# Altium schematic sheet Eco-Stationnement_Rampe.SchDoc(Eco-Stationnement_Rampe)
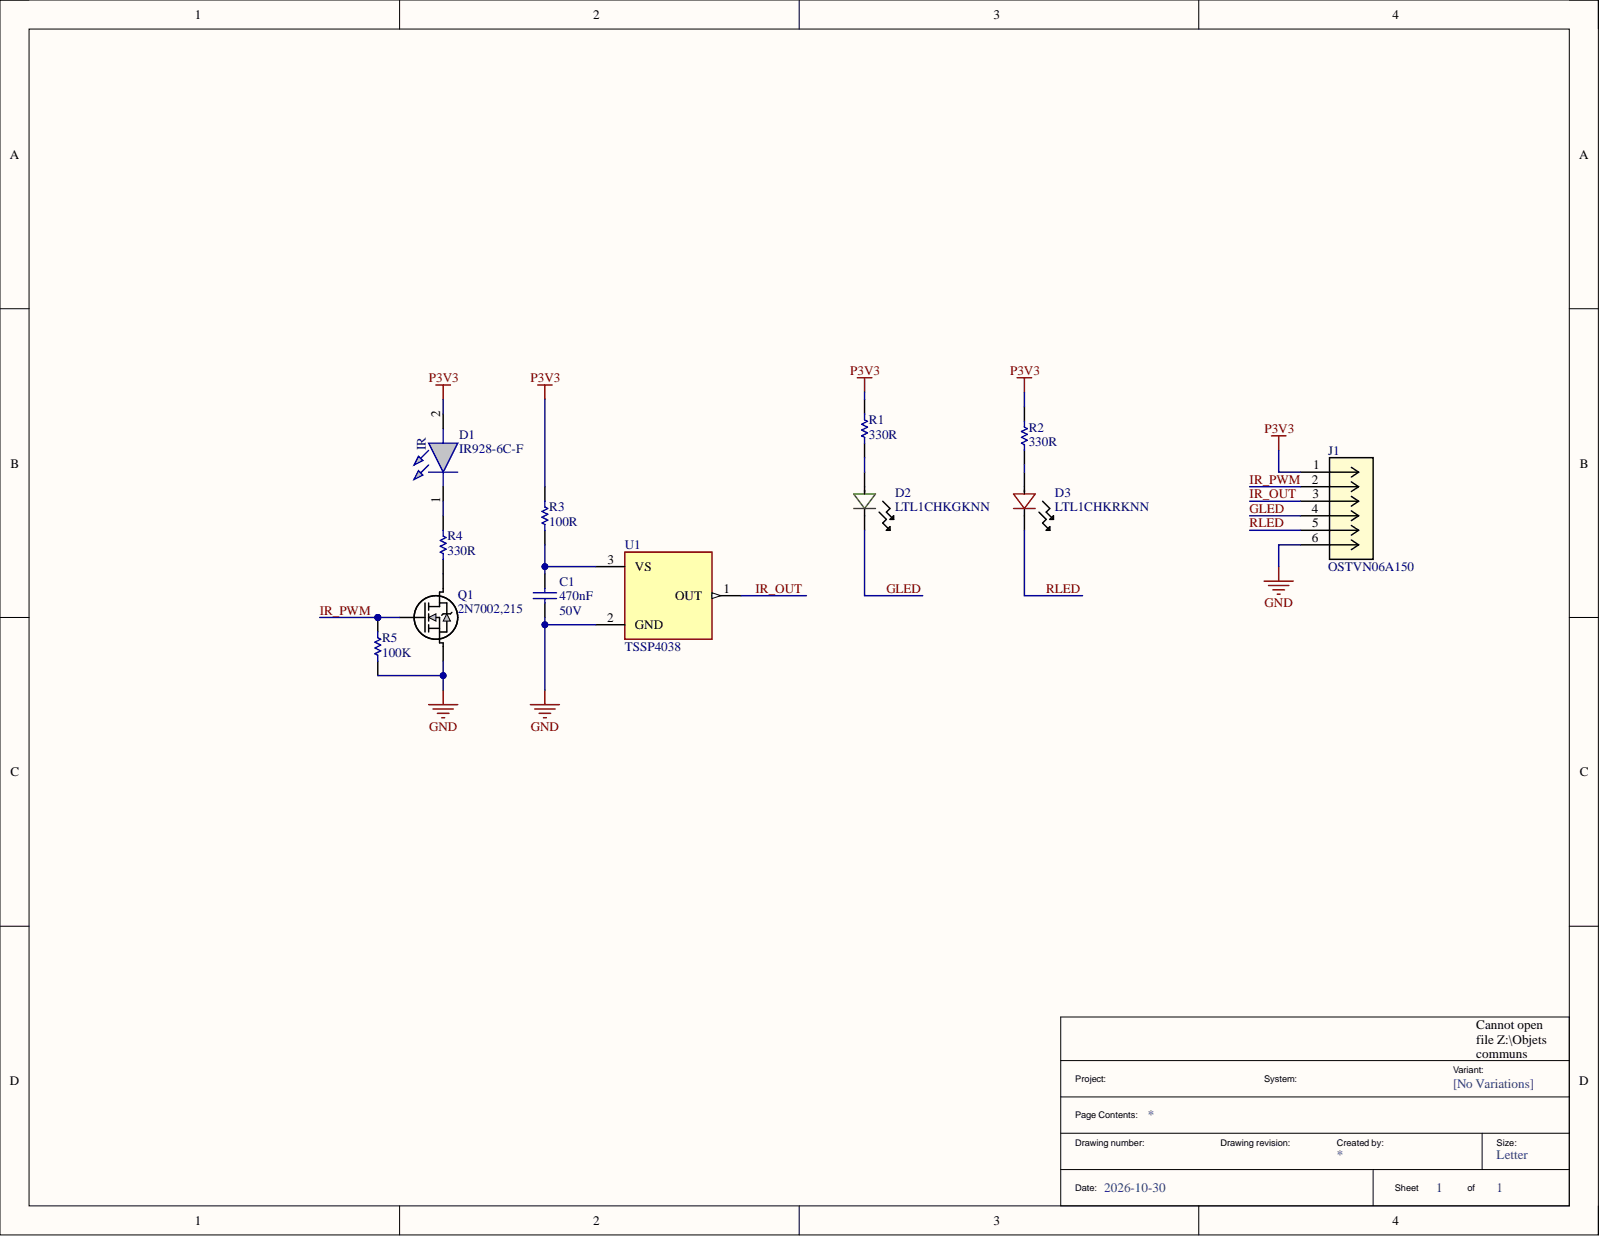
[diagram p0: Eco-Stationnement_Rampe.SchDoc]

## Parts
C1  value 470nF  Voltage: 50V  [C0603]  (hidden comment: C1608X5R1H474K080AB)
D1  IR928-6C-F  [IR9286CF]
D2  LTL1CHKGKNN  [LED_SLA580_ROM]
D3  LTL1CHKRKNN  [LED_SLA580_ROM]
J1  OSTVN06A150  [CONN_OSTVN06A150_OST]
Q1  2N7002,215  [TO-236AB_NEX]
R1  value 330R  [R0603]  (hidden comment: RC0603FR-07330RL)
R2  value 330R  [R0603]  (hidden comment: RC0603FR-07330RL)
R3  value 100R  [R0603]  (hidden comment: AC0603FR-07100RL)
R4  value 330R  [R0603]  (hidden comment: RC0603FR-07330RL)
R5  value 100K  [R0603]  (hidden comment: RC0603FR-07100KL)
U1  TSSP4038  [XDCR_TSSP4038]

## Nets
GND: C1.1 J1.6 Q1.2 R5.1 U1.2
P3V3: D1.2 J1.1 R1.2 R2.2 R3.2
GLED: D2.1 J1.4
IR_OUT: J1.3 U1.1
IR_PWM: J1.2 Q1.1 R5.2
RLED: D3.1 J1.5
NetC1_2: C1.2 R3.1 U1.3
NetD1_1: D1.1 R4.2
NetD2_2: D2.2 R1.1
NetD3_2: D3.2 R2.1
NetQ1_3: Q1.3 R4.1

## Library data of the parts
C1 = CAP0124: CAP CER 0.47UF 50V X5R 0603; Manufacturer: Walsin Technology Corporation; ID_CEP: MCAP0124; Verif_1: RCA; Component Kind: Standard; Fitted: Fitted; Package: 0603; PN_Manuf: C1608X5R1H474K080AB; Library Name: Eco-Stationnement_Rampe.SchLib
D1 = IR928-6C-F: Infrared Emitter 940nm Circular Right Angle 2-Pin Bag; Manufacturer: Everlight; Geometry.Height: 4.7mm; Component Kind: Standard; Datasheet Link: https://www.mouser.fr/ProductDetail/Everlight/IR928-6C-F?qs=8PzhAHr7IdNp8oknw19ZjQ%3D%3D; Fitted: Fitted; Manufacturer_Name: Everlight; Manufacturer_Part_Number: IR928-6C-F; Mouser Part Number: 638-IR9286CF; Mouser Price/Stock: https://www.mouser.co.uk/ProductDetail/Everlight/IR928-6C-F?qs=8PzhAHr7IdNp8oknw19ZjQ%3D%3D; PN_Manuf: IR928-6C-F; Library Name: Eco-Stationnement_Rampe.SchLib
D2 = LTL1CHKGKNN: LED GREEN CLEAR T-1 T/H; Manufacturer_Name: Lite-On Inc.; Component Kind: Standard; Manufacturer_Part_Number: LTL1CHKGKNN; Copyright: Copyright (C) 2026 Ultra Librarian. All rights reserved.; Library Name: Eco-Stationnement_Rampe.SchLib
D3 = LTL1CHKRKNN: LED RED CLEAR T-1 T/H; Manufacturer_Name: Lite-On Inc.; Component Kind: Standard; Manufacturer_Part_Number: LTL1CHKRKNN; Copyright: Copyright (C) 2026 Ultra Librarian. All rights reserved.; Library Name: Eco-Stationnement_Rampe.SchLib
J1 = OSTVN06A150: TERM BLK 6P SIDE ENT 2.54MM PCB; Manufacturer: On Shore Technology Inc.; Manufacturer_Name: On Shore Technology; Component Kind: Standard; Fitted: Fitted; Manufacturer_Part_Number: OSTVN06A150; PN_Manuf: OSTVN06A150; RefDes: RefDes; Type: Type; Copyright: Copyright (C) 2025 Ultra Librarian. All rights reserved.; Library Name: Eco-Stationnement_Rampe.SchLib
Q1 = TRA0001: MOSFET N-CH 60V 300MA TO236AB; Manufacturer: Nexperia; Verif_1: GC; Component Kind: Standard; Fitted: Fitted; ID_CEP: TRA0001; Manufacturer_Name: Nexperia; Manufacturer_Part_Number: 2N7002,215; PN_Manuf: 2N7002,215; Copyright: Copyright (C) 2025 Ultra Librarian. All rights reserved.; Library Name: Eco-Stationnement_Rampe.SchLib
R1 R2 R4 = RES0053: RES 330 OHM 1% 1/10W 0603; Manufacturer: YAGEO; ID_CEP: MRES0053; Verif_1: JSMG; Component Kind: Standard; Cost: 0.01; Fitted: Fitted; LatestRevisionDate: 17-Jul-2002; LatestRevisionNote: Re-released for DXP Platform.; Package: 0603; PackageDescription: Axial Device, Thru-Hole; 2 Leads; 0.3 in Pin Spacing; PackageReference: AXIAL-0.3; PN_Manuf: RC0603FR-07330RL; Published: 8-Jun-2000; Library Name: Eco-Stationnement_Rampe.SchLib; Publisher: Altium Limited
R3 = RES0018: RES 100 OHM 1% 1/10W 0603; Manufacturer: Yageo; ID_CEP: MRES0018; Verif_1: RCA le 2022-03-16; Component Kind: Standard; Fitted: Fitted; Package: 0603; PN_Manuf: AC0603FR-07100RL; Library Name: Eco-Stationnement_Rampe.SchLib
R5 = RES0003: RES SMD 100K OHM 1% 1/10W 0603; Manufacturer: YAGEO; ID_CEP: MRES0003; Verif_1: JSMG; Component Kind: Standard; Cost: 0.01; Fitted: Fitted; LatestRevisionDate: 17-Jul-2002; LatestRevisionNote: Re-released for DXP Platform.; Package: 0603; PackageDescription: Axial Device, Thru-Hole; 2 Leads; 0.3 in Pin Spacing; PackageReference: AXIAL-0.3; PN_Manuf: RC0603FR-07100KL; Published: 8-Jun-2000; Library Name: Eco-Stationnement_Rampe.SchLib; Publisher: Altium Limited
U1 = TSSP4038: Remote Receiver Sensor, 38.0kHz 1m PCB, Through Hole; Manufacturer: Vishay Semiconductor; Check_prices: https://www.snapeda.com/parts/TSSP4038/Vishay/view-part/?ref=eda; Component Kind: Standard; Fitted: Fitted; MF: Vishay Semiconductor; MP: TSSP4038; Package: None; PN_Manuf: TSSP4038; SnapEDA_Link: https://www.snapeda.com/parts/TSSP4038/Vishay/view-part/?ref=snap; Library Name: Eco-Stationnement_Rampe.SchLib
